# Altium schematic sheet drvinput3.SchDoc(drvinput3)
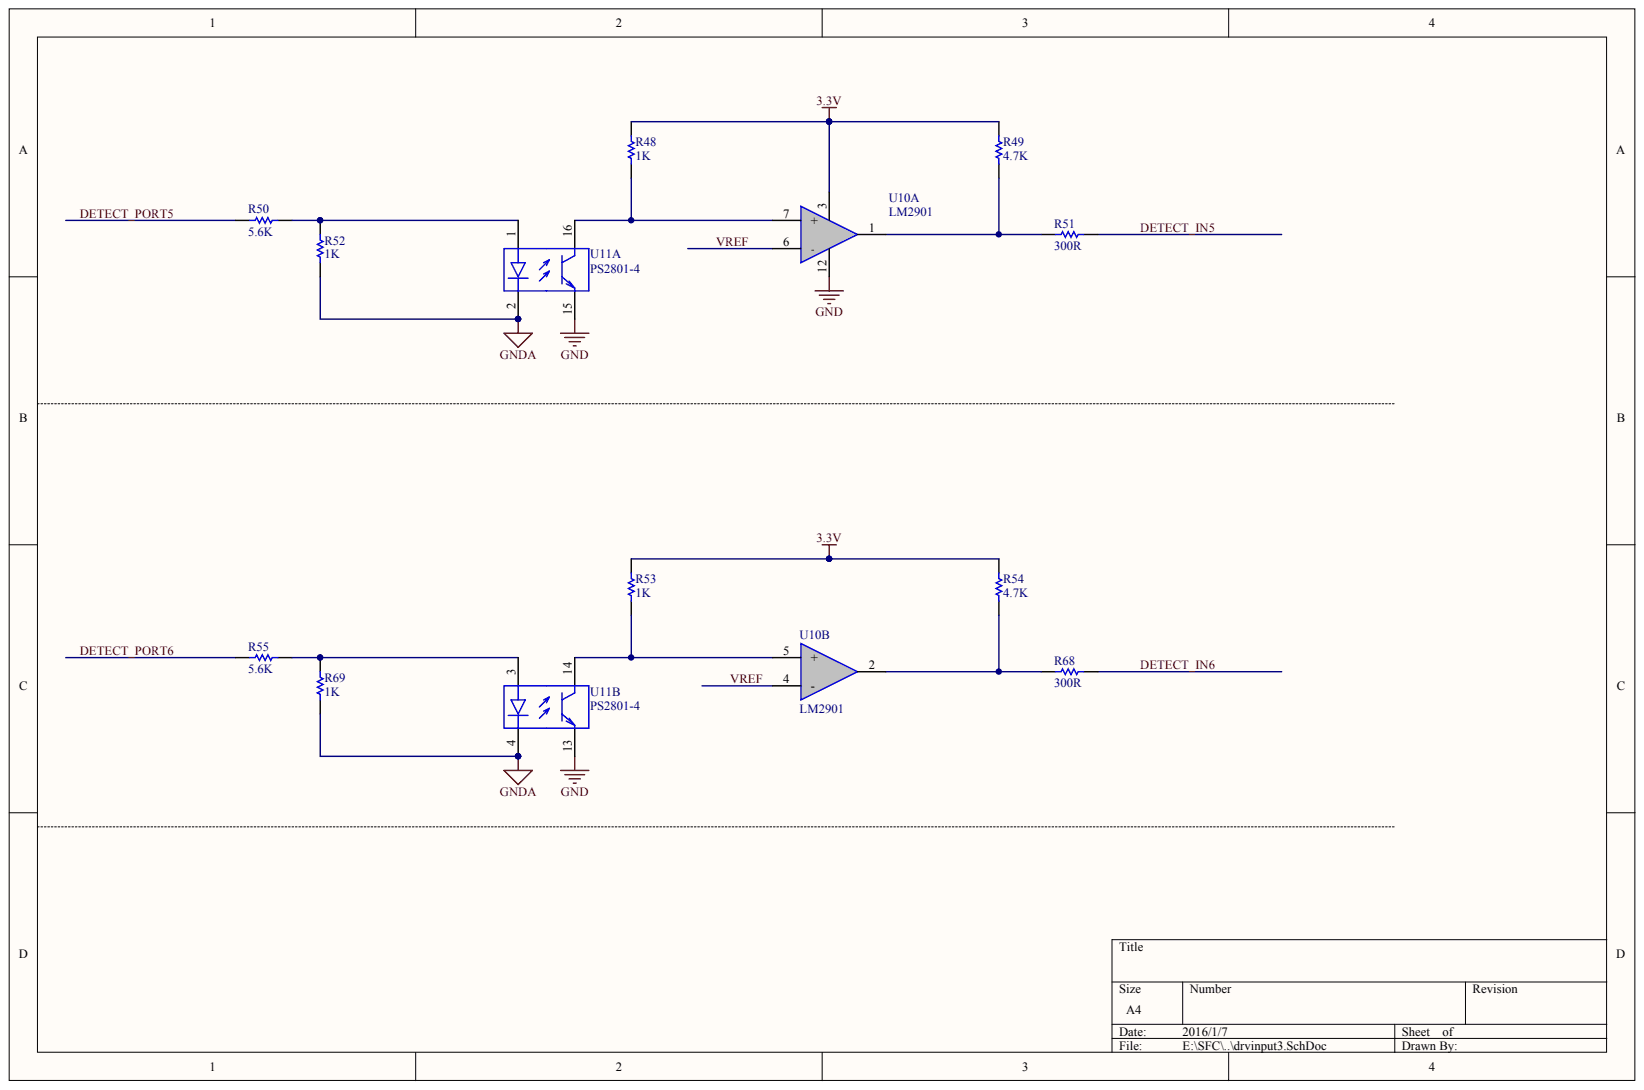
[diagram p0: drvinput3.SchDoc]

## Parts
R48  1K
R49  4.7K
R50  5.6K
R51  300R
R52  1K
R53  1K
R54  4.7K
R55  5.6K
R68  300R
R69  1K
U10  LM2901  (parts A,B)
U11  PS2801-4  (parts A,B)

## Nets
3.3V: R48.1 R49.2 R53.1 R54.2 U10.3
GND: U10.12 U11.13 U11.15
GNDA: R52.1 R69.1 U11.2 U11.4
DETECT_IN5: R51.1
DETECT_IN6: R68.2
DETECT_PORT5: R50.2
DETECT_PORT6: R55.2
VREF: U10.4(-) U10.6(-)
NetR48_2: R48.2 U10.7(+) U11.16
NetR49_1: R49.1 R51.2 U10.1
NetR50_1: R50.1 R52.2 U11.1
NetR53_2: R53.2 U10.5(+) U11.14
NetR54_1: R54.1 R68.1 U10.2
NetR55_1: R55.1 R69.2 U11.3

## Library data of the parts
R48-R55 R69 = (no symbol name): Value: 10K; Published: 8-Jun-2000; LatestRevisionDate: 17-Jul-2002; LatestRevisionNote: Re-released for DXP Platform.; PackageReference: AXIAL-0.3; Publisher: Altium Limited
R68 = (no symbol name): Value: 470; Published: 8-Jun-2000; LatestRevisionDate: 17-Jul-2002; LatestRevisionNote: Re-released for DXP Platform.; PackageReference: AXIAL-0.3; Publisher: Altium Limited
U10 U11 = (no symbol name)
  U11: Published: 28-Feb-2003; LatestRevisionDate: 13-Apr-2006; LatestRevisionNote: IPC-7351 Footprint Added.; DatasheetVersion: Jan-2002, Rev. 7; PackageVersion: rev7, Jan-2002; PackageReference: 751-07; PackageDescription: 8-Pin Plastic Small Outline Integrated Circuit 1.27 mm Pitch; Code_JEDEC: MS-012-AA; Code_IPC: SOIC127P600-8; ComponentLink1Description: Manufacturer Link; ComponentLink1URL: http://www.onsemi.com/; ComponentLink2Description: Datasheet; ComponentLink2URL: http://www.onsemi.com/pub/Collateral/LM358-D.PDF; Publisher: Altium Limited
